# Altium schematic sheet Stage3C_digital_pot.SchDoc
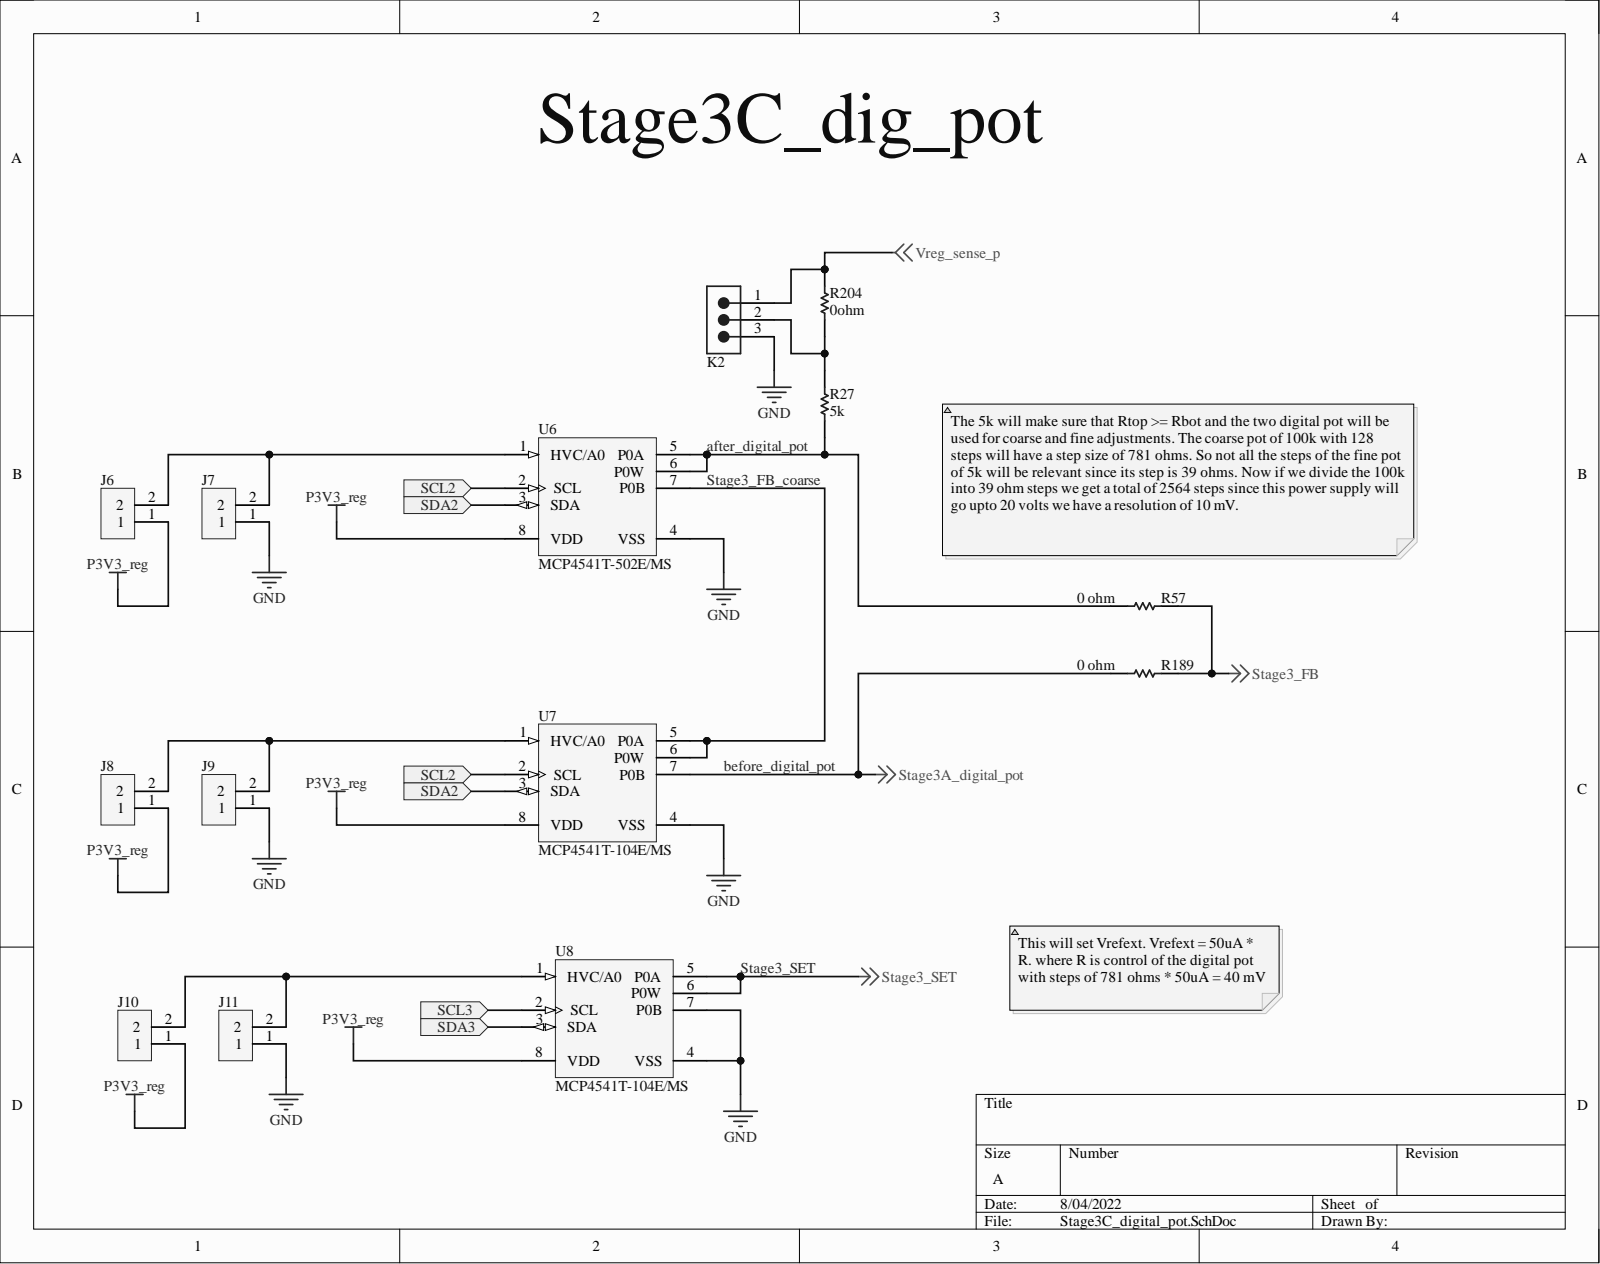
[diagram p0: Stage3C_digital_pot.SchDoc]

## Parts
J6  (no value)  [PCB-omgsbkhp90n7lpri88og-1]
J7  (no value)  [PCB-omgsbkhp90n7lpri88og-1]
J8  (no value)  [PCB-omgsbkhp90n7lpri88og-1]
J9  (no value)  [PCB-omgsbkhp90n7lpri88og-1]
J10  (no value)  [PCB-omgsbkhp90n7lpri88og-1]
J11  (no value)  [PCB-omgsbkhp90n7lpri88og-1]
K2  jump  [PCB-mldu0kavgw0gzp5zputm-1]  (value not drawn)
R27  5k  [PCB-uip3f7nxj24hdkeeu2m5-1]
R57  0 ohm  [RESC2013X60X35NL10T20]
R189  0 ohm  [RESC2013X60X35NL10T20]
R204  0ohm  [RESC2013X60X35NL10T20]
U6  MCP4541T-502E/MS  [MSOP-MS8_N]
U7  MCP4541T-104E/MS  [MSOP-MS8_L]
U8  MCP4541T-104E/MS  [MSOP-MS8_L]

## Ports
SCL2, SCL3, SDA2, SDA3

## Nets
GND: J7.1 J9.1 J11.1 K2.3 U6.4(VSS) U7.4(VSS) U8.4(VSS) U8.7(P0B)
P3V3_reg: J6.1 J8.1 J10.1 U6.8(VDD) U7.8(VDD) U8.8(VDD)
Stage3_FB: R57.2 R189.2
Vreg_sense_p: K2.1 R204.2
after_digital_pot: R27.1 R57.1 U6.5(P0A) U6.6(P0W)
before_digital_pot: R189.1 U7.7(P0B)
SCL2: U6.2(SCL) U7.2(SCL) ; port SCL2
SCL3: U8.2(SCL) ; port SCL3
SDA2: U6.3(SDA) U7.3(SDA) ; port SDA2
SDA3: U8.3(SDA) ; port SDA3
Stage3_FB_coarse: U6.7(P0B) U7.5(P0A) U7.6(P0W)
Stage3_SET: U8.5(P0A) U8.6(P0W)
NetJ6_2: J6.2 J7.2 U6.1(HVC/A0)
NetJ8_2: J8.2 J9.2 U7.1(HVC/A0)
NetJ10_2: J10.2 J11.2 U8.1(HVC/A0)
NetK2_2: K2.2 R27.2 R204.1

## Library data of the parts
J6-J11 = CMP-748fbcb73d6bab48-30: Pitch 2.54 mm, Single Row, 2pins; Component Kind: Standard; Library Name: My Personal Space
K2 = CMP-8a90426ffa175557-43: THT Vertical Pin Header WR-PHD, Pitch 2.54 mm, Single Row, 3 pins; Manufacturer Part Number: 61300311121; Contact Resistance: 20.0 mΩ; Current Rating: 3.00 A; Dielectric Withstanding Voltage: 500 V; Gender: Male; Lead-Free Status: Lead Free; Number of Contacts: 3; Operating Voltage: 250 V; Pin Pitch: 2.54 mm; Color: Black; Component Kind: Standard; Contact Material: Copper; Contact Plating: Gold; Library Name: My Personal Space; Mounting Style: Through Hole; Number of Pins: 3; Octopart URL: https://octopart.com/61300311121-w%C3%BCrth+elektronik-32855521; OctopartID: 8a90426ffa175557; Operating Temperature: -40.0 °C (min); Orientation: Vertical; Packaging: Bag; REACH SVHC Compliance: No SVHC; RoHS: Compliant
R27 = CMP-68e4a35bf371b723-1: Res Thick Film 0805 0.82 Ohm 5% 0.25W(1/4W) ±200ppm/C Epoxy SMD Automotive T/R; Manufacturer Part Number: RLP73K2AR82JTD; Case/Package (SI): 2012; ELV Compliant: Compliant; Power Rating: 250 mW; Resistance: 820 mΩ; Case/Package: 0805, 2012; Component Kind: Standard; Library Name: My Personal Space; Lifecycle Status: Not Listed by Manufacturer; Mounting Style: Surface Mount; Octopart URL: http://octopart.com/rlp73k2ar82jtd-te+connectivity-45465331; OctopartID: 68e4a35bf371b723; Packaging: Tape & Reel (TR); REACH SVHC Compliance: No SVHC; Resistance Tolerance: ±5%; RoHS: Compliant
R57 R189 R204 = CMP-5eab975428dd816c-2: Res Thick Film 0805 0 Ohm Jumper Molded SMD T/R; Manufacturer Part Number: CRG0805ZR; Case/Package (SI): 2012; ELV Compliant: Compliant; Power Rating: 125 mW; Resistance: 0.00 Ω; Case/Package: 0805; Component Kind: Standard; Library Name: My Personal Space; Lifecycle Status: Active; Mounting Style: Surface Mount; Octopart URL: https://octopart.com/crg0805zr-te+connectivity-16077584; OctopartID: 5eab975428dd816c; Packaging: Tape & Reel (TR); RoHS: Compliant
U6 = CMP-1074023-3: 7-Bit Single I2C Digital POT with Non-Volatile Memory, 8-pin MSOP, Extended Temperature, Tape and Reel; Resistance Tolerance: ±20%; Component Kind: Standard; Library Name: My Personal Space; Lifecycle Status: Active; Manufacturer Part Number: MCP4541T-502E/MS; Octopart Url: https://octopart.com/mcp4541t-502e%2Fms-microchip-16787150; OctopartID: 3faff7a53c07e068; RoHS: Compliant
U7 U8 = CMP-1074022-3: 7-Bit Single I2C Digital POT with Non-Volatile Memory, 8-pin MSOP, Extended Temperature, Tape and Reel; Resistance Tolerance: ±20%; Component Kind: Standard; Library Name: My Personal Space; Lifecycle Status: Active; Manufacturer Part Number: MCP4541T-104E/MS; Octopart Url: https://octopart.com/mcp4541t-104e%2Fms-microchip-16096136; OctopartID: a1f1680e1f1f7e36; RoHS: Compliant
